# Altium schematic sheet T_module.SchDoc(T_module)
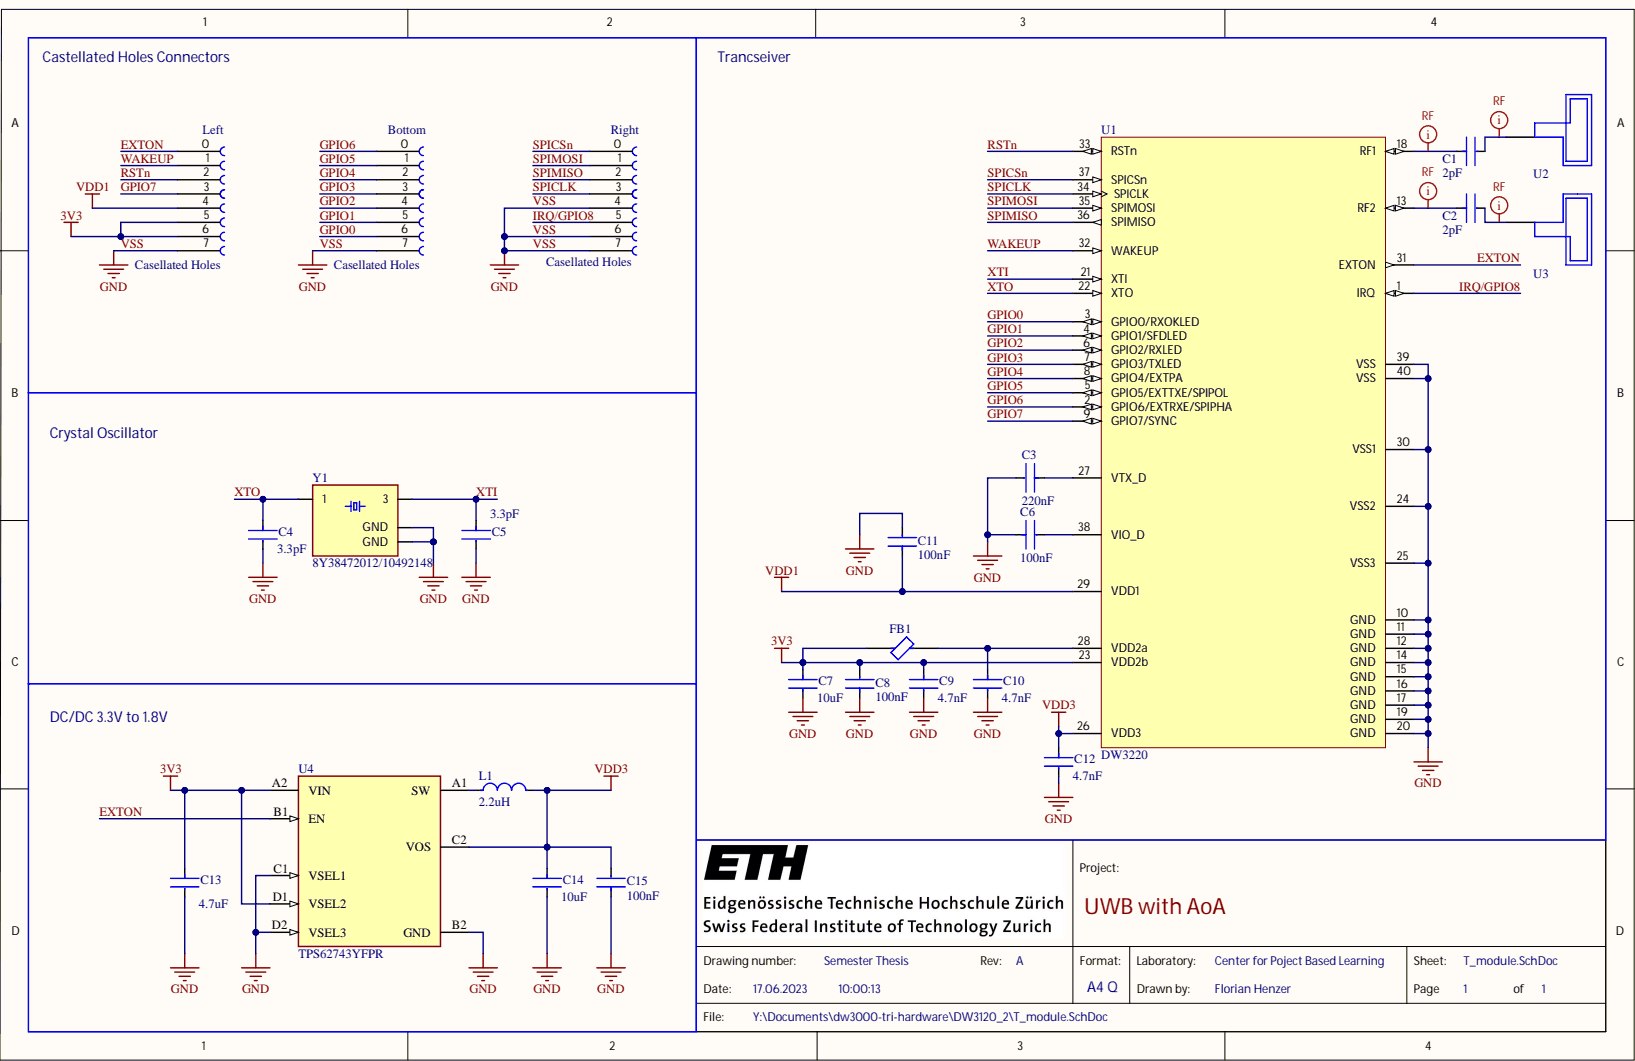
[diagram p0: T_module.SchDoc]

## Parts
Bottom  Casellated Holes  [8 Castelted holes]
C1  Capacitance: 2pF  [FP-GJM033-0_03-IPC_B]  (hidden comment: GJM0335C1E2R0WB01D)
C2  Capacitance: 2pF  [FP-GJM033-0_03-IPC_B]  (hidden comment: GJM0335C1E2R0WB01D)
C3  Capacitance: 220nF  [FP-CL03-IPC_B]  (hidden comment: CL03A224KQ3NNNC)
C4  Capacitance: 3.3pF  [FP-GRM033-0_03-IPC_A]  (hidden comment: GRM0335C1H3R3CA01D)
C5  Capacitance: 3.3pF  [FP-GRM033-0_03-IPC_A]  (hidden comment: GRM0335C1H3R3CA01D)
C6  Capacitance: 100nF  [FP-CL03-IPC_B]  (hidden comment: CL03A104KQ3NNNH)
C7  Capacitance: 10uF  [FP-GRM155-0_2-0_1-IPC_B]  (hidden comment: GRM155R60J106ME47D)
C8  Capacitance: 100nF  [FP-CL03-IPC_B]  (hidden comment: CL03A104KQ3NNNH)
C9  Capacitance: 4.7nF  [FP-GRM033-0_03-IPC_A]  (hidden comment: GRM033R71A472KA01D)
C10  Capacitance: 4.7nF  [FP-GRM033-0_03-IPC_A]  (hidden comment: GRM033R71A472KA01D)
C11  Capacitance: 100nF  [FP-CL03-IPC_B]  (hidden comment: CL03A104KQ3NNNH)
C12  Capacitance: 4.7nF  [FP-GRM033-0_03-IPC_A]  (hidden comment: GRM033R71A472KA01D)
C13  Capacitance: 4.7uF  [FP-GRM035-0_09-IPC_B]  (hidden comment: GRM035R60J475ME15D)
C14  Capacitance: 10uF  [FP-GRM155-0_2-0_1-IPC_C]  (hidden comment: GRM155R60J106ME47D)
C15  Capacitance: 100nF  [FP-CL03-IPC_B]  (hidden comment: CL03A104KQ3NNNH)
FB1  MPZ0603S330HT000  [FP-MPZ0603-IPC_B]  (value not drawn)
L1  Inductance: 2.2uH  [FP-DFE201610C-IPC_C]  (hidden comment: 1285AS-H-2R2M=P2)
Left  Casellated Holes  [8 Castelted holes]
Right  Casellated Holes  [8 Castelted holes]
U1  DW3220  [QFN40P500X500X40_HS-40N]
U2  AH086M555003-T  [AH086M]  (value not drawn)
U3  AH086M555003-T  [AH086M]  (value not drawn)
U4  TPS62743YFPR  [FP-YFP0008-C01-MFG]
Y1  8Y38472012/10492148  [OSCSC320X250X100N - small Footprint]

## Nets
3V3: C7.1 C8.2 C9.1 C13.1 FB1.1 Left.5 Left.6 U1.23(VDD2b) U4.A2(VIN) U4.D1(VSEL2)
VDD1: C11.1 Left.4 U1.29(VDD1)
VDD3: C12.1 C14.1 C15.2 L1.2 U1.26(VDD3) U4.C2(VOS)
EXTON: Left.0 U1.31(EXTON) U4.B1(EN)
GPIO0: Bottom.6 U1.3(GPIO0/RXOKLED)
GPIO1: Bottom.5 U1.4(GPIO1/SFDLED)
GPIO2: Bottom.4 U1.6(GPIO2/RXLED)
GPIO3: Bottom.3 U1.7(GPIO3/TXLED)
GPIO4: Bottom.2 U1.8(GPIO4/EXTPA)
GPIO5: Bottom.1 U1.5(GPIO5/EXTTXE/SPIPOL)
GPIO6: Bottom.0 U1.2(GPIO6/EXTRXE/SPIPHA)
GPIO7: Left.3 U1.9(GPIO7/SYNC)
IRQ/GPIO8: Right.5 U1.1(IRQ)
RSTn: Left.2 U1.33(RSTn)
SPICLK: Right.3 U1.34(SPICLK)
SPICSn: Right.0 U1.37(SPICSn)
SPIMISO: Right.2 U1.36(SPIMISO)
SPIMOSI: Right.1 U1.35(SPIMOSI)
VSS: Bottom.7 C3.2 C4.2 C5.2 C6.1 C7.2 C8.1 C9.2 C10.2 C11.2 C12.2 C13.2 C14.2 C15.1 Left.7 Right.4 Right.6 Right.7 U1.10(GND) U1.11(GND) U1.12(GND) U1.14(GND) U1.15(GND) U1.16(GND) U1.17(GND) U1.19(GND) U1.20(GND) U1.24(VSS2) U1.25(VSS3) U1.30(VSS1) U1.39(VSS) U1.40(VSS) U4.B2(GND) U4.C1(VSEL1) U4.D2(VSEL3) Y1.2(GND) Y1.4(GND)
WAKEUP: Left.1 U1.32(WAKEUP)
XTI: C5.1 U1.21(XTI) Y1.3
XTO: C4.1 U1.22(XTO) Y1.1
NetC1_1: C1.1 U1.18(RF1)
NetC1_2: C1.2 U2.1
NetC2_1: C2.1 U1.13(RF2)
NetC2_2: C2.2 U3.1
NetC3_1: C3.1 U1.27(VTX_D)
NetC6_2: C6.2 U1.38(VIO_D)
NetC10_1: C10.1 FB1.2 U1.28(VDD2a)
NetL1_1: L1.1 U4.A1(SW)

## Library data of the parts
C1 C2 = CMP-2010-00751-2: Ceramic Capacitor 2pF ±0.05pF 25V C0G 0201 (0603 Metric); Case Code (Imperial): 0201; Case Code (Metric): 0603; Case/Package: 0201; Component Kind: Standard; Depth: 0.3mm; Dielectric: C0G; Height: 0.3mm; Length: 0.6mm; Library Name: Altium Content Vault; Max Operating Temperature: 125°C; Min Operating Temperature: -55°C; Mount: Surface Mount; Package Quantity: 15000; Packaging: Tape and Reel; Radiation Hardening: No; Termination: SMD/SMT; Thickness: 0.013inch; Voltage Rating: 25V; Voltage Rating (DC): 25V; Width: 0.012inch
C3 = CMP-2010-00678-2: Cap Ceramic 220nF 6.3V X5R ±10% Pad SMD 0201 +85°C T/R; Case Code (Imperial): 0201; Case Code (Metric): 0603; Case/Package: 0201; Component Kind: Standard; Contact Plating: Tin; Depth: 0.3mm; Dielectric: X5R; Height: 0.3mm; Length: 0.6mm; Library Name: Altium Content Vault; Max Operating Temperature: 85°C; Min Operating Temperature: -55°C; Mount: Surface Mount; Packaging: Tape and Reel; Radiation Hardening: No; Thickness: 0.013inch; Tolerance: 10%; Voltage Rating: 6.3V; Width: 0.012inch
C4 C5 = CMP-2010-01116-2: Chip Multilayer Ceramic Capacitors for General Purpose, 0201, 3.3pF, C0G, 30ppm/°C, 0.25pF, 50V; Case Code (Imperial): 0201; Case Code (Metric): 0603; Case/Package: 0201; Component Kind: Standard; Depth: 0.3mm; Dielectric: C0G; Lead Free: Lead Free; Length: 0.024inch; Library Name: Altium Content Vault; Max Operating Temperature: 125°C; Min Operating Temperature: -55°C; Mount: Surface Mount; Package Quantity: 1; Packaging: Tape and Reel; Termination: SMD/SMT; Thickness: 0.013inch; Voltage Rating: 50V; Voltage Rating (DC): 50V; Width: 0.012inch
C6 C8 C11 C15 = CMP-13271-001518-1: Cap Ceramic 100nF 6.3V X5R ±10% Pad SMD 0201 +85°C T/R; Case Code (Imperial): 0201; Case Code (Metric): 0603; Case/Package: 0201; Component Kind: Standard; Depth: 0.3mm; Dielectric: X5R; Height: 0.3mm; Length: 0.6mm; Library Name: Altium Content Vault; Max Operating Temperature: 85°C; Min Operating Temperature: -55°C; Mount: Surface Mount; Package Quantity: 15000; Packaging: Tape and Reel; Radiation Hardening: No; Thickness: 0.013inch; Tolerance: 10%; Voltage Rating: 6.3V; Width: 0.012inch
C7 C14 = CMP-06035-022355-1: Chip Multilayer Ceramic Capacitors for General Purpose, 0402, 10uF, X5R, 15%, 20%, 6.3V; Case Code (Imperial): 0402; Case Code (Metric): 1005; Case/Package: 0402; Component Kind: Standard; Dielectric: X5R; Length: 0.039inch; Library Name: Altium Content Vault; Max Operating Temperature: 85°C; Min Operating Temperature: -55°C; Mount: Surface Mount; Packaging: Tape and Reel; Thickness: 0.024inch; Tolerance: 20%; Voltage Rating: 6.3V; Voltage Rating (DC): 6.3V; Width: 0.02inch
C9 C10 C12 = CMP-2010-01686-2: Chip Multilayer Ceramic Capacitors for General Purpose, 0201, 4700pF, X7R, 15%, 10%, 10V; Case Code (Imperial): 0201; Case Code (Metric): 0603; Case/Package: 0201; Component Kind: Standard; Depth: 0.3mm; Dielectric: X7R; Height: 0.3mm; Lead Free: Lead Free; Length: 0.6mm; Library Name: Altium Content Vault; Material: Ceramic; Max Operating Temperature: 125°C; Min Operating Temperature: -55°C; Mount: Surface Mount; Package Quantity: 1; Packaging: Tape and Reel; Radiation Hardening: No; REACH SVHC: No SVHC; Termination: SMD/SMT; Thickness: 0.013inch; Tolerance: 10%; Voltage: 10V; Voltage Rating: 10V; Voltage Rating (DC): 10V; Width: 0.012inch
C13 = CMP-06035-019701-1: Chip Multilayer Ceramic Capacitors for General Purpose, 0201, 4.7uF, X5R, 15%, 20%, 6.3V; Case Code (Imperial): 0201; Case Code (Metric): 0603; Case/Package: 0201; Component Kind: Standard; Dielectric: X5R; Length: 0.024inch; Library Name: Altium Content Vault; Max Operating Temperature: 85°C; Min Operating Temperature: -55°C; Mount: Surface Mount; Packaging: Tape and Reel; Thickness: 0.022inch; Tolerance: 20%; Voltage Rating: 6.3V; Voltage Rating (DC): 6.3V; Width: 0.012inch
FB1 = CMP-08257-000038-1: CHIP BEADS FOR GENERAL SIGNAL LI; DC Resistance (DCR): 50mR; Max Current Rating: 1.6A; Number of Lines: 1; Case Code (Imperial): 0201; Case Code (Metric): 0603; Case/Package: 0201; Component Kind: Standard; Library Name: Altium Content Vault; Max Operating Temperature: 125°C; Min Operating Temperature: -55°C; Mount: Surface Mount; Packaging: Cut Tape; RoHS Compliant: Yes
L1 = CMP-06042-000201-1: Shielded Wirewound Inductor 2.2uH 20% 1200mA 0.204Ω 0806 (2016); Core Material: Ferrite; Current Rating: 1.5A; DC Resistance (DCR): 204mR; Max DC Current: 1.2A; Test Frequency: 1MHz; Case Code (Imperial): 0805; Case Code (Metric): 2012; Case/Package: 0805; Component Kind: Standard; Depth: 1.6mm; Height - Seated (Max): 0.039inch; Length: 0.079inch; Library Name: Altium Content Vault; Max Operating Temperature: 85°C; Min Operating Temperature: -40°C; Mount: Surface Mount; Packaging: Tape and Reel; Resistor Type: Wirewound; Shielding: Shielded; Tolerance: 20%; Width: 0.063inch
U1 = CMP-01416-1: Ultra-low power Wi-Fi 802.11b/g/n, without mounted antenna; Manufacturer: Qorvo; Part # Aliases: DWM3000; 802.15 Standards: 4a, 4z-BPRF; Angle of Arrival(degrees): < 5; Antenna Ports(#): 2; BOM Ext Components(#): 10; Data Rate: 850 kbps, 6.8 Mbps; Interface: SPI; MCU: External; Package(mm): 5 x 5; PDoA Support: Yes; Ranging Accuracy(cm): < 10; Security Features: 4z Compliant + AES; UWB Channels: 5, 9; Voltage(V): 2.4 to 3.6; Component Kind: Standard; Library Name: ETHZ Vault; Package Type: QFN; Product Type: RF Transceiver; Series: DWM3000; Subcategory: Wireless & RF Integrated Circuits
U2 U3 = CMP-00997-1: 3.5GHz, 5.6GHz WiMax™ Chip RF Antenna 3.1GHz ~ 8GHz 2.6dBi, 0.7dBi Solder Surface Mount; Frequency: 5.55GHz; Impedance: 50R; Number of Bands: 1; Component Kind: Standard; Height: 0.039inch; Length: 8mm; Library Name: ETHZ Vault; Max Frequency: 8GHz; Max Operating Temperature: 80°C; Min Operating Temperature: -20°C; Mount: Surface Mount; Package Quantity: 1; Packaging: Tape and Reel; Radiation Hardening: No; REACH SVHC: Unknown; Termination: Solder; Width: 6mm
U4 = CMP-04918-000507-1: IC REG BUCK PROG 300MA 8DSBGA; Max Input Voltage: 5.5V; Max Output Voltage: 3.3V; Min Input Voltage: 2.15V; Min Output Voltage: 1.2V; Number of Outputs: 1; Output Configuration: Positive; Quiescent Current: 300nA; Switching Frequency: 1.2MHz; Synchronous Rectifier: Yes; Component Kind: Standard; Lead Free: Lead Free; Library Name: Altium Content Vault; Max Operating Temperature: 85°C; Min Operating Temperature: -40°C; Mount: Surface Mount; Output Current: 300mA; Packaging: Tape and Reel; RoHS Compliant: Yes
Y1 = ABM8G-14.31818MHZ-B4Y-T: https://www.digikey.ch/de/products/detail/txc-corporation/8Y38472012/10492148; Availability: Unavailable; Component Kind: Standard; Library Name: ABM8G-14.31818MHZ-B4Y-T.SchLib; MP: 8Y38472012/10492148; Package: None; Price: None
Bottom Left Right = Casellated Holes: Component Kind: Standard; Library Name: Schlib1.SchLib
